# Altium schematic sheet MINIECO-ECO.SchDoc(MINIECO-ECO)
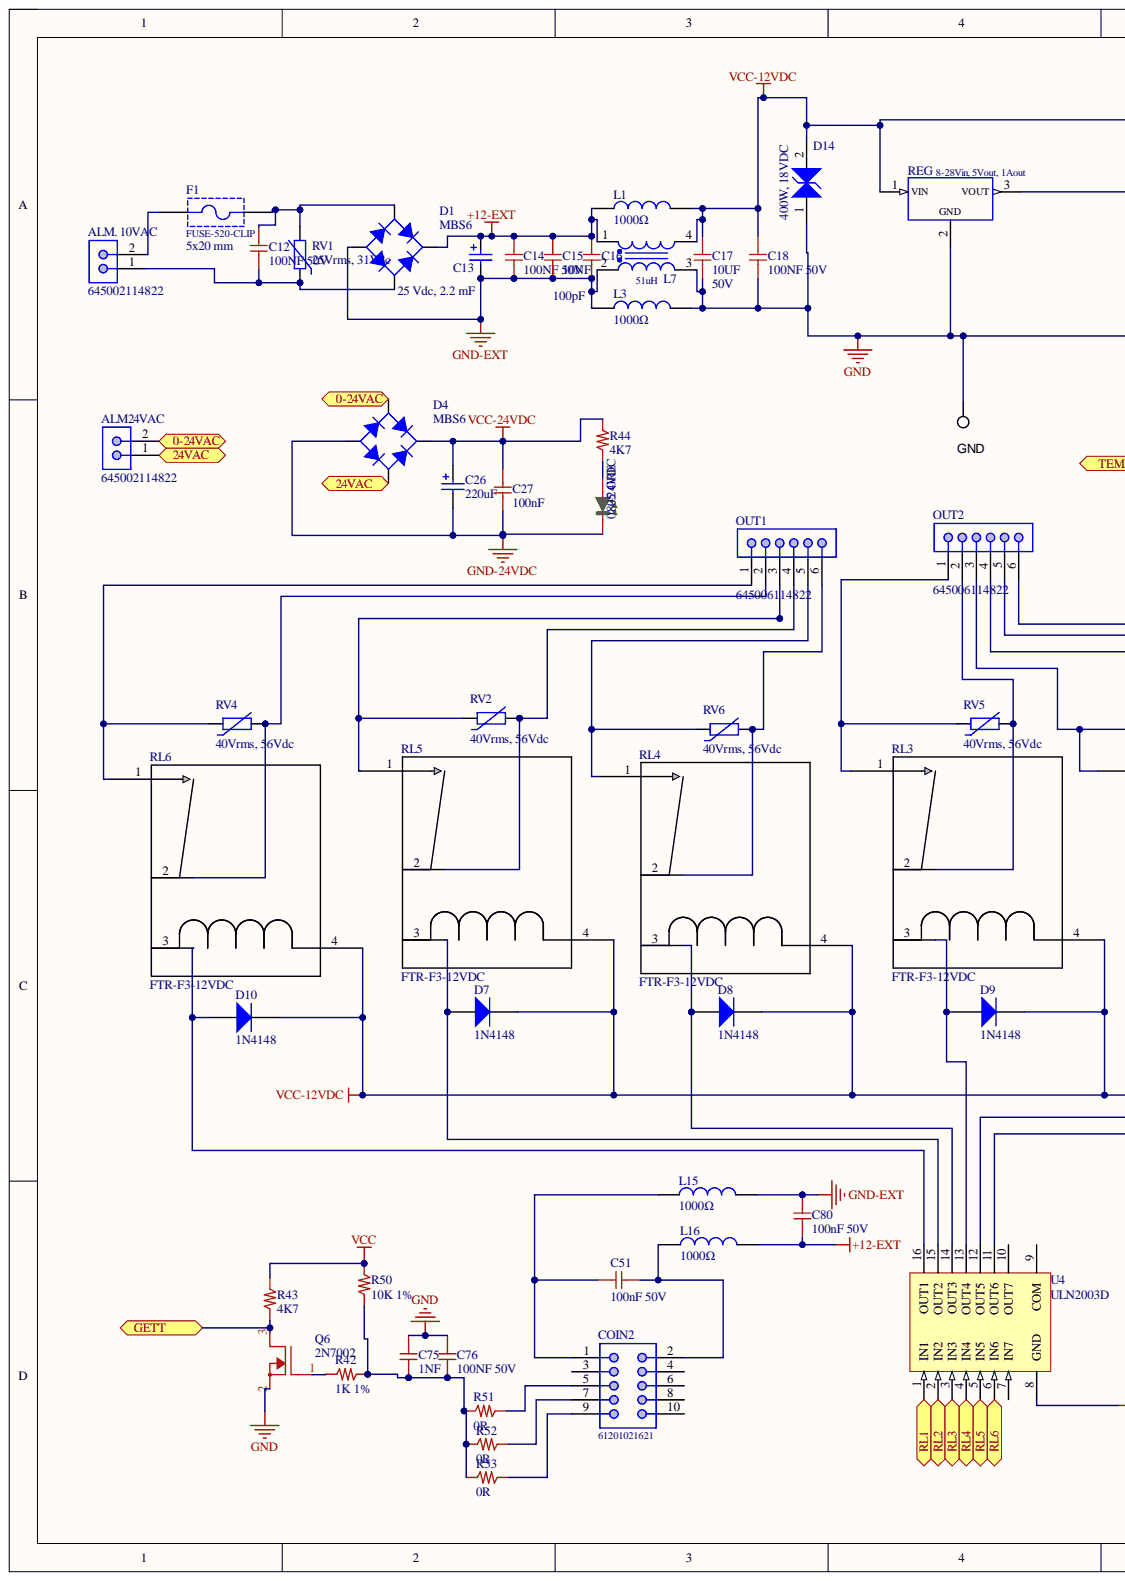
[diagram p0: MINIECO-ECO.SchDoc, left]
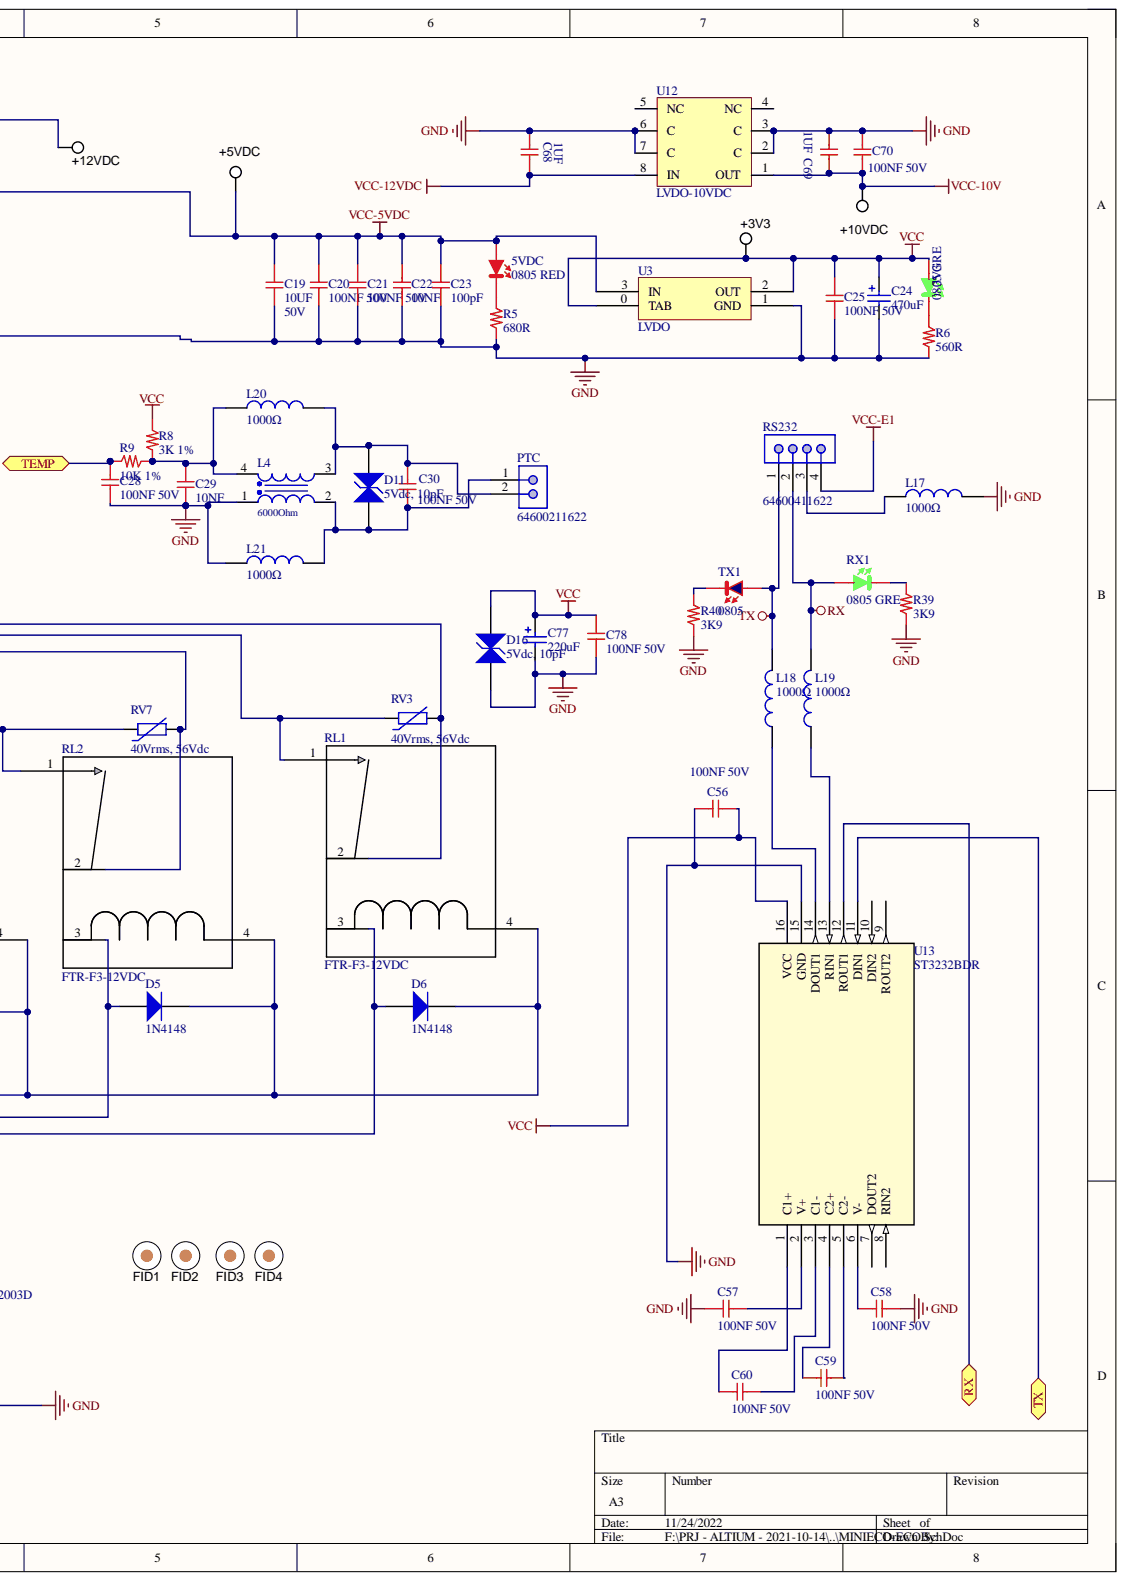
[diagram p1: MINIECO-ECO.SchDoc, right]

## Parts
3V3  0805 GRE  [0805_Green]
5VDC  0805 RED  [0805_Red]
+3V3  TEST POINT  [Keystone5012]  (value not drawn)
+5VDC  TEST POINT  [Keystone5012]  (value not drawn)
+10VDC  TEST POINT  [Keystone5012]  (value not drawn)
+12VDC  TEST POINT  [Keystone5012]  (value not drawn)
+24VDC  0805 GRE  [0805_Green]
ALM24VAC  645002114822  [645002114822]
ALM._10VAC  645002114822  [645002114822]
C12  100NF 50V  [0603_c]
C13  25 Vdc, 2.2 mF  value 2.2 mF  [WCAP-ATLI_13x35x5]
C14  100NF 50V  [0603_c]
C15  10NF  [0603_c]
C16  100pF  [0603_c]
C17  10UF  Tensione: 50V  [1206_C]
C18  100NF 50V  [0603_c]
C19  10UF  Tensione: 50V  [1206_C]
C20  100NF 50V  [0603_c]
C21  100NF 50V  [0603_c]
C22  10NF  [0603_c]
C23  100pF  [0603_c]
C24  value 470uF  [WCAP-ASLL_8x10.5]  (hidden comment: 865060253008)
C25  100NF 50V  [0603_c]
C26  value 220uF  [WCAP-ASLL_10x10.5]  (hidden comment: 865060657012)
C27  100nF  [0805_C]
C28  100NF 50V  [0603_c]
C29  10NF  [0603_c]
C30  100NF 50V  [0603_c]
C51  100nF 50V  [0603_c]
C56  100NF 50V  [0603_c]
C57  100NF 50V  [0603_c]
C58  100NF 50V  [0603_c]
C59  100NF 50V  [0603_c]
C60  100NF 50V  [0603_c]
C68  1UF  [0805_C]
C69  1UF  [0805_C]
C70  100NF 50V  [0603_c]
C75  1NF  [0603_c]
C76  100NF 50V  [0603_c]
C77  value 220uF  [WCAP-ASLL_10x10.5]  (hidden comment: 865060657012)
C78  100NF 50V  [0603_c]
C80  100nF 50V  [0603_c]
COIN2  61201021621  [61201021621]
D1  MBS6  [HD01-T SOP4]
D4  MBS6  [HD01-T SOP4]
D5  1N4148  [1N4148]
D6  1N4148  [1N4148]
D7  1N4148  [1N4148]
D8  1N4148  [1N4148]
D9  1N4148  [1N4148]
D10  1N4148  [1N4148]
D11  5Vdc, 10pF  [WE-VE_0603]
D14  400W, 18VDC  [WE-TVSP_DO-214AC_Bidirectional]
D16  5Vdc, 10pF  [WE-VE_0603]
F1  FUSE-520-CLIP  Fuse Size: 5x20 mm  [FUSE-520-CLIP]
FID1  Fiducial  [Fiducial10-20]  (value not drawn)
FID2  Fiducial  [Fiducial10-20]  (value not drawn)
FID3  Fiducial  [Fiducial10-20]  (value not drawn)
FID4  Fiducial  [Fiducial10-20]  (value not drawn)
GND  TEST POINT  [Keystone5012]  (value not drawn)
L1  1000Ω  [WE-CBF_0805_Wide Band]
L3  1000Ω  [WE-CBF_0805_Wide Band]
L4  6000Ohm  [WE-CNSW_1206]
L7  51uH  [WE-SL2]
L15  1000Ω  [WE-CBF_0805_Wide Band]
L16  1000Ω  [WE-CBF_0805_Wide Band]
L17  1000Ω  [WE-CBF_0805_Wide Band]
L18  1000Ω  [WE-CBF_0805_Wide Band]
L19  1000Ω  [WE-CBF_0805_Wide Band]
L20  1000Ω  [WE-CBF_0805_Wide Band]
L21  1000Ω  [WE-CBF_0805_Wide Band]
OUT1  645006114822  [645006114822]
OUT2  645006114822  [645006114822]
PTC  64600211622  [64600211622]
Q6  2N7002  [SOT23]
R5  680R  [0603_R]
R6  560R  [0603_R]
R8  3K 1%  [0805_R]
R9  10K 1%  [0603_R]
R39  3K9  [0603_R]
R40  3K9  [0603_R]
R42  1K 1%  [0603_R]
R43  4K7  [0603_R]
R44  4K7  [2512_R]
R50  10K 1%  [0603_R]
R51  0R  [0603_R]
R52  0R  [0603_R]
R53  0R  [0603_R]
REG  8-28Vin, 5Vout, 1Aout  [173010x78]
RL1  FTR-F3-12VDC  [PAN_ALD]
RL2  FTR-F3-12VDC  [PAN_ALD]
RL3  FTR-F3-12VDC  [PAN_ALD]
RL4  FTR-F3-12VDC  [PAN_ALD]
RL5  FTR-F3-12VDC  [PAN_ALD]
RL6  FTR-F3-12VDC  [PAN_ALD]
RS232  64600411622  [64600411622]
RV1  25Vrms, 31Vdc  [WE-VD_7mm_820572501]
RV2  40Vrms, 56Vdc  DC Operating Voltage: 56Vdc  [WE-VD_7mm_820574001]
RV3  40Vrms, 56Vdc  DC Operating Voltage: 56Vdc  [WE-VD_7mm_820574001]
RV4  40Vrms, 56Vdc  DC Operating Voltage: 56Vdc  [WE-VD_7mm_820574001]
RV5  40Vrms, 56Vdc  DC Operating Voltage: 56Vdc  [WE-VD_7mm_820574001]
RV6  40Vrms, 56Vdc  DC Operating Voltage: 56Vdc  [WE-VD_7mm_820574001]
RV7  40Vrms, 56Vdc  DC Operating Voltage: 56Vdc  [WE-VD_7mm_820574001]
RX1  0805 GRE  [0805_Green]
TX1  0805  [0805-BLU]
U3  LVDO  [SOT-223]
U4  ULN2003D  [SO16_N]
U12  LVDO-10VDC  [SO-8]
U13  ST3232BDR  [D16]

## Ports
0-24VAC, 24VAC, GETT, RL1, RL2, RL3, RL4, RL5, RL6, RX, TEMP, TX

## Nets
+12-EXT: C13.1 C14.1 C15.1 C16.1 C80.1 D1.1 L1.1 L7.1 L16.2
GND: C17.2 C18.2 C19.2 C20.2 C21.2 C22.2 C23.2 C24.2 C25.2 C28.2 C29.2 C56.1 C57.1 C58.2 C68.1 C69.1 C70.1 C75.1 C76.1 C77.2 C78.2 D14.1 D16.2 GND.1 L3.2 L4.1 L7.3 L17.2 L21.1 Q6.2 R5.2 R6.2 R39.1 R40.1 REG.2 U3.1(GND) U4.8(GND) U12.2(C) U12.3(C) U12.6(C) U12.7(C) U13.15(GND)
GND-24VDC: +24VDC.K C26.2 C27.2 D4.2
GND-EXT: C13.2 C14.2 C15.2 C16.2 C80.2 D1.2 L3.1 L7.2 L15.2
RX: L19.2 RS232.2 RX1.A
TX: L18.2 RS232.1 TX1.A
VCC: 3V3.A +3V3.1 C24.1 C25.1 C56.2 C77.1 C78.1 D16.1 R8.1 R43.1 R50.2 U3.0(TAB) U3.2(OUT) U13.16(VCC)
VCC-5VDC: 5VDC.A +5VDC.1 C19.1 C20.1 C21.1 C22.1 C23.1 REG.3 U3.3(IN)
VCC-10V: +10VDC.1 C69.2 C70.2 U12.1(OUT)
VCC-12VDC: +12VDC.1 C17.1 C18.1 C68.2 D5.2 D6.2 D7.2 D8.2 D9.2 D10.2 D14.2 L1.2 L7.4 REG.1 RL1.4 RL2.4 RL3.4 RL4.4 RL5.4 RL6.4 U12.8(IN)
VCC-24VDC: C26.1 C27.1 D4.1 R44.1
VCC-E1: RS232.4
0-24VAC: ALM24VAC.2 D4.4 ; port 0-24VAC
24VAC: ALM24VAC.1 D4.3 ; port 24VAC
GETT: Q6.3 R43.2 ; port GETT
RL1: U4.1(IN1) ; port RL1
RL2: U4.2(IN2) ; port RL2
RL3: U4.3(IN3) ; port RL3
RL4: U4.4(IN4) ; port RL4
RL5: U4.5(IN5) ; port RL5
RL6: U4.6(IN6) ; port RL6
TEMP: C28.1 R9.1 ; port TEMP
Net3V3_K: 3V3.K R6.1
Net5VDC_K: 5VDC.K R5.1
Net+24VDC_A: +24VDC.A R44.2
NetALM. 10VAC_1: ALM._10VAC.1 C12.2 D1.3 RV1.1
NetALM. 10VAC_2: ALM._10VAC.2 F1.1
NetC12_1: C12.1 D1.4 F1.2 RV1.2
NetC29_1: C29.1 L4.4 L20.1 R8.2 R9.2
NetC30_1: C30.1 D11.1 L4.2 L21.2 PTC.1
NetC30_2: C30.2 D11.2 L4.3 L20.2 PTC.2
NetC51_1: C51.1 COIN2.1 L15.1
NetC51_2: C51.2 COIN2.2 L16.1
NetC57_2: C57.2 U13.2(V+)
NetC58_1: C58.1 U13.6(V-)
NetC59_1: C59.1 U13.4(C2+)
NetC59_2: C59.2 U13.5(C2-)
NetC60_1: C60.1 U13.1(C1+)
NetC60_2: C60.2 U13.3(C1-)
NetC75_2: C75.2 C76.2 R42.2 R50.1 R51.1 R52.2 R53.1
NetCOIN2_5: COIN2.5 R51.2
NetCOIN2_7: COIN2.7 R52.1
NetCOIN2_9: COIN2.9 R53.2
NetD5_1: D5.1 RL2.3 U4.12(OUT5)
NetD6_1: D6.1 RL1.3 U4.11(OUT6)
NetD7_1: D7.1 RL5.3 U4.15(OUT2)
NetD8_1: D8.1 RL4.3 U4.14(OUT3)
NetD9_1: D9.1 RL3.3 U4.13(OUT4)
NetD10_1: D10.1 RL6.3 U4.16(OUT1)
NetL17_1: L17.1 RS232.3
NetL18_1: L18.1 U13.14(DOUT1)
NetL19_1: L19.1 U13.13(RIN1)
NetOUT1_1: OUT1.1 RL6.1 RV4.1
NetOUT1_2: OUT1.2 RL6.2 RV4.2
NetOUT1_3: OUT1.3 RL5.1 RV2.1
NetOUT1_4: OUT1.4 RL5.2 RV2.2
NetOUT1_5: OUT1.5 RL4.1 RV6.1
NetOUT1_6: OUT1.6 RL4.2 RV6.2
NetOUT2_1: OUT2.1 RL3.1 RV5.1
NetOUT2_2: OUT2.2 RL3.2 RV5.2
NetOUT2_3: OUT2.3 RL2.1 RV7.1
NetOUT2_4: OUT2.4 RL2.2 RV7.2
NetOUT2_5: OUT2.5 RL1.1 RV3.1
NetOUT2_6: OUT2.6 RL1.2 RV3.2
NetQ6_1: Q6.1 R42.1
NetR39_2: R39.2 RX1.K
NetR40_2: R40.2 TX1.K
NetU13_11: U13.11(DIN1) ; port TX
NetU13_12: U13.12(ROUT1) ; port RX

Not connected: COIN2 3 4 6 8 10; U4 7(IN7) 9(COM) 10(OUT7); U12 4(NC) 5(NC); U13 7(DOUT2) 8(RIN2) 9(ROUT2) 10(DIN2)

## Library data of the parts
3V3 +24VDC RX1 = LED_Verde_0805: LED Verde 0805; Cod. Interno: LEDS 9AC080GS7500; Cod. Produttore: 150080GS75000; Luminosità: 450mcd; Produttore: WE; V: 3.1V; Component Kind: Standard; Library Name: DiodiLED.SchLib
5VDC = LED_ROSSO_0805: LED Rosso 0805; Cod. Interno: LEDS 2AC080RS7500; Cod. Produttore: 150080RS75000; Luminosità: 150mcd; Produttore: WE; V: 2.0V; Component Kind: Standard; Library Name: DiodiLED.SchLib
+3V3 +5VDC +10VDC +12VDC GND = TP-HSW: Test Point, Multipurpose, White, TH; Manufacturer: Keystone; PartNumber: 5012; CompType: Test Point Loop; ContactFinish: Silver; Color: White; Component Kind: Standard; ComponentLink1Description: Datasheet URL; ComponentLink1URL: http://www.keyelco.com/userAssets/file/M60-2p60.pdf; LatestRevisionDate: 2017-01-19; Library Name: HSW.SchLib; MountType: TH; PackageReference: White Multipurpose Testpoint; RoHS: Y; Supplier 1: Digi-Key; Supplier 2: Mouser; Supplier Part Number 1: 36-5012-ND; Supplier Part Number 2: 534-5012; SymbolVersion: 2008-04-04; Publisher: Texas Instruments
ALM24VAC ALM._10VAC = 645002114822: WR-WTB THT Male Vertical Locking Header, pitch 3.96mm, 2p; Contact Resistance: 20mOhm; Pin Number: 2; Rated Current: 7A; Withstanding Voltage: 1500VAC; Working Voltage: 250VAC; Component Kind: Standard; ComponentLink1Description: Manufacturer; ComponentLink1URL: http://www.we-online.de; ComponentLink2Description: Datasheet; ComponentLink2URL: https://www.we-online.com/catalog/datasheet/645002114822.pdf; Gender: Male; Library Name: WR-WTB_3.96mm.SchLib; Mounting Technology: THT; Operating Temperature: -40 to +105degC; Packaging: Bag; Part Number: 645002114822; Pitch: 3.96mm; Published Date: January 2020; Type: Vertical; Publisher: Wurth Elektronik
C12 C14 C18 C20 C21 C25 C28 C30 C51 C56-C60 C70 C76 C78 C80 = 100NF 50V: Cap X7R 100nF 50V Flex Terminal; Cod. Interno: COCS50665050104; Cod. Produttore: CL10B104KB8SFNC; Produttore: Samsung; Cod. DigiKey: 1276-1936-2-ND; Component Kind: Standard; Library Name: CapSMD0603.SchLib
C13 = 860080478024: WCAP-ATLI Aluminum Electrolytic Capacitors, 13x35mm, 25 Vdc, 2.2 mF; Rated Voltage: 25 Vdc; Value: 2.2 mF; Component Kind: Standard; ComponentLink1Description: Manufacturer; ComponentLink1URL: http://www.we-online.de; ComponentLink2Description: Datasheet; ComponentLink2URL: http://katalog.we-online.com/pbs/datasheet/860080478024.pdf; Library Name: WCAP-ATLI.SchLib; Life Cycle: 5000h; Operating Temperature: -55 °C up to +105 °C; Part Number: 860080478024; Published Date: Sep. 2017; Size: 13x35mm; Publisher: Wurth Elektronik
C15 C22 C29 = 10NF 50V: Cap X7R 10nF 50V; Cod. Interno: COCS 556655000103; Cod. Produttore: CL05B103KB5NNNC; Produttore: Samsung; Cod. DigiKey: 1276-1028-2-ND; Component Kind: Standard; Library Name: CapSMD0603.SchLib
C16 C23 = 100pF 50V: Cap X7R 100pF 50V; Cod. Interno: COCS??; Cod. Produttore: ??; Component Kind: Standard; Library Name: CapSMD0603.SchLib; Produttore: ??
C17 C19 = 10UF 50V: Cap X5R 10UF 50V; Cod. Produttore: GRM31CR61H106KA12L; Produttore: MURATA; Cod. Farnell: 2666583; Component Kind: Standard; Library Name: CapSMD1206.SchLib; Temperature Range: Da -55°C a +125°C
C24 = 865060253008: WCAP-ASLL Aluminum Electrolytic Capacitors, size Ф8x10.5mm, 10V DC, 470uF; Rated Voltage: 10V DC; Component Kind: Standard; ComponentLink1Description: Manufacturer; ComponentLink1URL: http://www.we-online.de; ComponentLink2Description: Datasheet; ComponentLink2URL: http://katalog.we-online.de/pbs/datasheet/865060253008.pdf; Library Name: WCAP-ASLL.SchLib; Life Cycle: 5000h; Operating Temperature: -55 °C up to +105 °C; Part Number: 865060253008; Published Date: June 2017; Size: Ф8x10.5mm; Publisher: Wurth Elektronik
C26 C77 = 865060657012: WCAP-ASLL Aluminum Electrolytic Capacitors, size Ф10x10.5mm, 50V DC, 220uF; Rated Voltage: 50V DC; Component Kind: Standard; ComponentLink1Description: Manufacturer; ComponentLink1URL: http://www.we-online.de; ComponentLink2Description: Datasheet; ComponentLink2URL: http://katalog.we-online.de/pbs/datasheet/865060657012.pdf; Library Name: WCAP-ASLL.SchLib; Life Cycle: 5000h; Operating Temperature: -55 °C up to +105 °C; Part Number: 865060657012; Published Date: June 2017; Size: Ф10x10.5mm; Publisher: Wurth Elektronik
C27 = 100nF 100V: Cap X7R 100nF 100V; Cod. Interno: COCS 557051010104; Cod. Produttore: ??; Component Kind: Standard; Library Name: CapSMD0805.SchLib; Produttore: ??
C68 C69 = 1UF 100V: Cap X7S 1UF 100V; Cod. Interno: COCS 567061010105; Cod. Produttore: C2012X7S2A105K125AE; Produttore: TDK; Cod. DigiKey: 445-8887-2-ND; Component Kind: Standard; Library Name: CapSMD0805.SchLib; Temperature Range: Da -55°C a +125°C
C75 = 1NF 50V: Cap X7R 1nF 50V; Cod. Interno: COCS 50665050102; Component Kind: Standard; Library Name: CapSMD0603.SchLib
COIN2 = 61201021621: Male Box Header WR-BHD, THT, Vertical, pitch 2.54 mm, 10 pins; Contact Resistance: 20 mOhm; Pin Number: 10; Pitch: 2.54 mm; Withstanding Voltage: 500 VAC; Working Voltage: 250 VAC; Component Kind: Standard; ComponentLink1Description: Manufacturer; ComponentLink1URL: http://www.we-online.de; ComponentLink2Description: Datasheet; ComponentLink2URL: https://katalog.we-online.de/em/datasheet/6120xx21621.pdf; Gender: Male; Mounting Technology: THT; Operating Temperature: -40 to 125 degC; Orientation: Vertical; Packaging: Tray; Part Number: 61201021621; Published Date: August 2018; Library Name: CONN-FLAT.SchLib; Publisher: Wurth Elektronik
D1 D4 = HD01-T: Full Wave Diode Bridge; Component Kind: Standard; Library Name: HSW.SchLib; Publisher: Altium Limited
D5-D10 = 1N4148: High Conductance Fast Diode; Code_JEDEC: DO-204-AH; Component Kind: Standard; ComponentLink1Description: Manufacturer Link; ComponentLink1URL: http://www.fairchildsemi.com/; ComponentLink2Description: Datasheet; ComponentLink2URL: http://www.fairchildsemi.com/ds/1N%2F1N4148.pdf; DatasheetVersion: 1997; LatestRevisionDate: 17-Jul-2002; LatestRevisionNote: Re-released for DXP Platform.; PackageDescription: Diode, Axial; Body 3.8 x 1.9 mm (LxDia. max), Lead Dia. 0.53 mm (max); PackageReference: DO-35; Published: 8-Jun-2000; Library Name: HSW.SchLib; Publisher: Altium Limited
D11 D16 = 82356050100: WE-VE ESD Suppressor, size 0603, 5Vdc, 10pF; Clamp Voltage: 60V; ESD Voltage air discharge: 15kV; Leakage Current: 1uA; Capacitance: 10pF; Component Kind: Standard; ComponentLink1Description: Manufacturer; ComponentLink1URL: http://www.we-online.de; ComponentLink2Description: Datasheet; ComponentLink2URL: https://katalog.we-online.com/pbs/datasheet/82356050100.pdf; Library Name: WE-VE_0603.SchLib; Mounting Technology: SMT; Operating Temperature: -40 to +85degC; Packaging: Tape and Reel; Part Number: 82356050100; Published Date: June 2019; Size: 0603; Working Voltage: 5Vdc; Publisher: Wurth Elektronik
D14 = 824501181: WE-TVSP Power TVS Diode, DO-214AC, 400W, 18VDC, Bidirectional; (Forward) Peak Pulse Current: 13.7A; (Reverse) Breakdown Voltage: 21.05V; Clamping Voltage: 29.2V; DC Operating Voltage: 18V; Component Kind: Standard; ComponentLink1Description: Manufacturer; ComponentLink1URL: http://www.we-online.de; ComponentLink2Description: Datasheet; ComponentLink2URL: https://katalog.we-online.com/pbs/datasheet/824501181.pdf; Library Name: WE-TVSP_DO-214AC_Bidirectional.SchLib; Mounting Technology: SMT; Operating Temperature: -65 to +150degC; Packaging: Tape and Reel; Part Number: 824501181; Polarity: Bidirectional; Published Date: March 2019; Size: DO-214AC; Steady State Power Dissipation: 400W; Publisher: Wurth Elektronik
F1 = FUSE-520-CLIP: LITTELFUSE-520 Series Circuit Board Mount Fuse Clips for 5mm & 6.3mm Diameter Fuses; Part Number: 696108003002; Component Kind: Standard; ComponentLink1Description: Manufacturer; ComponentLink1URL: http://www.we-online.de; ComponentLink2Description: Datasheet; ComponentLink2URL: https://katalog.we-online.de/em/datasheet/696108003002.pdf; Mounting Technology: THT; Operating Temperature: -30 to 120 degC; Packaging: Box; Published Date: August 2018; Library Name: HSW.SchLib; Publisher: Wurth Elektronik
FID1-FID4 = Fiducial: Fiducial mark.  There is nothing to buy or mount.; SymbolCreator: codlsc; ClassName: FiducialMark; Component Kind: Standard; CompType: Fiducial; LatestRevisionDate: 2015-10-18; LatestRevisionNote: Added Alternate PartNumber and Alternate Manufacturer parameters to appropriate components.; Manufacturer: N/A; MountType: N/A; PackageReference: N/A; PartNumber: N/A; SymbolVersion: 2009-11-4; Library Name: HSW.SchLib; Publisher: Texas Instruments
L1 L3 L15-L21 = WE-CBF, 74279205: WE-CBF SMD EMI Suppression Ferrite Bead, 0805, 1000Ω; Type: Wide Band; Component Kind: Standard; ComponentLink1Description: Manufacturer; ComponentLink1URL: http://www.we-online.de; ComponentLink2Description: Datasheet; ComponentLink2URL: http://katalog.we-online.de/pbs/datasheet/74279205.pdf; Library Name: WE-CBF_0805.SchLib; PartNumber: 74279205; PublishedDate: Aug 2016; Size: 0805; Value: 1000Ω; Publisher: Wurth Elektronik
L4 = 744232101: WE-CNSW SMT Common Mode Line Filter, size 1206, 6000Ohm, 0.09A, 50V; Manufacturer: Wurth Elektronik; DC Resistance max.: 5.5Ohm; Impedance Test Condition: 100MHz; Insulation Test Voltage: 125VAC; Winding Style: bifiliar; Component Kind: Standard; ComponentLink1Description: Manufacturer; ComponentLink1URL: http://www.we-online.de; ComponentLink2Description: Datasheet; ComponentLink2URL: https://www.we-online.com/catalog/datasheet/744232101.pdf; Impedance: 6000Ohm; Library Name: WE-CNSW_1206.SchLib; Manufacturer Part Number: 744232101; Mounting Technology: SMT; Operating Temperature: -40 to +125degC; Packaging: Tape and Reel; Published Date: April 2020; Rated Current: 0.09A; Rated Voltage: 50V; Size: 1206
L7 = 744227S: WE-SL2 SMT Common Mode Line Filter, 51uH, 1000mA, 80V; DC Resistance max.: 0.16Ohm; Impedance max.: 5500Ohm; Insulation Test Voltage: 500V (AC); Leakage Inductance: 3150nH; Winding Style: sectional; Component Kind: Standard; ComponentLink1Description: Manufacturer; ComponentLink1URL: http://www.we-online.de; ComponentLink2Description: Datasheet; ComponentLink2URL: https://katalog.we-online.com/pbs/datasheet/744227S.pdf; Inductance: 51uH; Library Name: WE-SL2.SchLib; Mounting Technology: SMT; Operating Temperature: -40 to +125degC; Packaging: Tape and Reel; Part Number: 744227S; Published Date: March 2019; Rated Current: 1000mA; Rated Voltage: 80V; Publisher: Wurth Elektronik
OUT1 OUT2 = 645006114822: WR-WTB THT Male Vertical Locking Header, pitch 3.96mm, 6p; Contact Resistance: 20mOhm; Pin Number: 6; Rated Current: 7A; Withstanding Voltage: 1500VAC; Working Voltage: 250VAC; Component Kind: Standard; ComponentLink1Description: Manufacturer; ComponentLink1URL: http://www.we-online.de; ComponentLink2Description: Datasheet; ComponentLink2URL: https://www.we-online.com/catalog/datasheet/645006114822.pdf; Gender: Male; Library Name: WR-WTB_3.96mm.SchLib; Mounting Technology: THT; Operating Temperature: -40 to +105degC; Packaging: Bag; Part Number: 645006114822; Pitch: 3.96mm; Published Date: January 2020; Type: Vertical; Publisher: Wurth Elektronik
PTC = 64600211622: WR-WTB Male Vertical Shrouded Header, pitch 2.5mm, 2p; Contact Resistance: 20mOhm; Pin Number: 2; Rated Current: 3A; Withstanding Voltage: 1000VAC; Working Voltage: 250VAC; Component Kind: Standard; ComponentLink1Description: Manufacturer; ComponentLink1URL: http://www.we-online.de; ComponentLink2Description: Datasheet; ComponentLink2URL: https://www.we-online.com/catalog/datasheet/64600211622.pdf; Gender: Male; Library Name: WR-WTB_2.50mm.SchLib; Mounting Technology: THT; Operating Temperature: -40 to +105degC; Packaging: Bag; Part Number: 64600211622; Pitch: 2.5mm; Published Date: January 2020; Type: Vertical; Publisher: Wurth Elektronik
Q6 = 2N7002: N-Channel 60V 0.3A MosFET; Cod. Interno: TRXS1100002N7002; Produttore: NXP; Cod. Produttore: 2N7002; Component Kind: Standard; Library Name: MosfetSMD.SchLib
R5 = 680R: Res Film Spesso 1/16W 680R 5%; Cod. Interno: RESS 4166556B0681; Component Kind: Standard; Library Name: ResSMD0603.SchLib
R6 = 560R: Res Film Spesso 1/16W 560R 5%; Cod. Interno: RESS 4166556B0561; Component Kind: Standard; Library Name: ResSMD0603.SchLib
R8 = 3K 1%: Res Film Spesso 0805 1/2W 3K 1%; Cod. Interno: ??; Component Kind: Standard; Library Name: ResSMD0805.SchLib
R9 R50 = 10K 1%: Res Film Spesso 1/16W 10K 1%; Cod. Interno: RESS4166356B0103; Cod. Produttore: ERJ-3EKF1002V; Produttore: Panasonic; Component Kind: Standard; Library Name: ResSMD0603.SchLib
R39 R40 = 3K9: Res Film Spesso 1/16W 3K9 5%; Cod. Interno: RESS4166556B0392; Cod. Produttore: CRCW06033K90JNEA; Produttore: VISHAY; Component Kind: Standard; Library Name: ResSMD0603.SchLib
R42 = 1K 1%: Res Film Spesso 1/16W 1K 1%; Cod. Interno: RESS4166356B0102; Cod. Produttore: ??; Component Kind: Standard; Library Name: ResSMD0603.SchLib; Produttore: ??
R43 = 4K7: Res Film Spesso 1/16W 4K7 5%; Cod. Interno: RESS4166556B0472; Component Kind: Standard; Library Name: ResSMD0603.SchLib
R44 = 4K7: Precision Thick Film 4K7 1W 1%; Cod. Interno: RESS 4190310A54R9; Cod. Produttore: ERJ-1TNF54R9U; Produttore: Vishay Dale; Cod. DigiKey: PT54.9AFTR-ND; Component Kind: Standard; Library Name: ResSMD2512.SchLib
R51-R53 = 0R: Res Film Spesso 1/16W 0R 5%; Cod. Interno: RESS 4166556B0000; Component Kind: Standard; Library Name: ResSMD0603.SchLib
REG = 173010578: MagI3C-FDSM Fixed Step Down Regulator Module, SIP-3, 8-28Vin, 5Vout, 1Aout; Input Voltage: 8-28V; Output Current: 1A; Output Voltage: 5V; Component Kind: Standard; ComponentLink1Description: Manufacturer; ComponentLink1URL: http://www.we-online.de; ComponentLink2Description: Datasheet; ComponentLink2URL: https://www.we-online.com/catalog/datasheet/173010578.pdf; Height: 10.5mm; Length: 11.6mm; Library Name: MagI3C-FDSM.SchLib; Manufacturer Part Number: 173010578; Mounting Technology: THT; Operating Temperature: -40 to +85degC; Packaging: Tube; Published Date: March 2020; Size: SIP-3; Width: 8.5mm; Publisher: Wurth Elektronik
RL1-RL6 = FTR-F3-12VDC: RELE NA 5A 250VAC COIL12VDC; Manufacturer_Part_Number: ALDP106W; Built_By: EMA_UL_Team; Vendor: Panasonic; Component Kind: Standard; Library Name: FTR-F3.SchLib; RefDes: RefDes; Type: DEV; Value: Value; Copyright: Copyright (C) 2018 Accelerated Designs. All rights reserved
RS232 = 64600411622: WR-WTB Male Vertical Shrouded Header, pitch 2.5mm, 4p; Contact Resistance: 20mOhm; Pin Number: 4; Rated Current: 3A; Withstanding Voltage: 1000VAC; Working Voltage: 250VAC; Component Kind: Standard; ComponentLink1Description: Manufacturer; ComponentLink1URL: http://www.we-online.de; ComponentLink2Description: Datasheet; ComponentLink2URL: https://www.we-online.com/catalog/datasheet/64600411622.pdf; Gender: Male; Library Name: WR-WTB_2.50mm.SchLib; Mounting Technology: THT; Operating Temperature: -40 to +105degC; Packaging: Bag; Part Number: 64600411622; Pitch: 2.5mm; Published Date: January 2020; Type: Vertical; Publisher: Wurth Elektronik
RV1 = 820572501: WE-VD Disk Varistor, size 7mm, 25Vrms, 31Vdc; (Channel) Input Capacitance: 1820pF; (Reverse) Breakdown Voltage: 39V; (Reverse) Peak Pulse Current: 250A; Clamping Voltage: 77V; Energy Absorbtion: 2.4J; Power Dissipation: 0.02W; AC Operating Voltage: 25Vrms; Component Kind: Standard; ComponentLink1Description: Manufacturer; ComponentLink1URL: http://www.we-online.de; ComponentLink2Description: Datasheet; ComponentLink2URL: https://katalog.we-online.com/pbs/datasheet/820572501.pdf; DC Operating Voltage: 31Vdc; Mounting Technology: THT; Operating Temperature: -40 to +85degC; Packaging: Tape and Reel; Part Number: 820572501; Published Date: March 2019; Size: 7mm; Library Name: MOV_7mm.SchLib; Publisher: Wurth Elektronik
RV2-RV7 = 820574001: WE-VD Disk Varistor, size 7mm, 40Vrms, 56Vdc; (Channel) Input Capacitance: 1120pF; (Reverse) Breakdown Voltage: 68V; (Reverse) Peak Pulse Current: 250A; Clamping Voltage: 135V; Energy Absorbtion: 4.3J; Power Dissipation: 0.02W; AC Operating Voltage: 40Vrms; Component Kind: Standard; ComponentLink1Description: Manufacturer; ComponentLink1URL: http://www.we-online.de; ComponentLink2Description: Datasheet; ComponentLink2URL: https://katalog.we-online.com/pbs/datasheet/820574001.pdf; Mounting Technology: THT; Operating Temperature: -40 to +85degC; Packaging: Tape and Reel; Part Number: 820574001; Published Date: March 2019; Size: 7mm; Library Name: MOV_7mm.SchLib; Publisher: Wurth Elektronik
TX1 = LED BLU 0805: Cod. Produttore: KPTR-3216QBC-D; Luminosità: 100mcd 20mA; Produttore: kingbright; Vf(typ): 3.3V; Component Kind: Standard; Description: LED BLU 0805; Library Name: DiodiLED.SchLib
U3 = LD1117S33TR: REGOLATORE IN; Component Kind: Standard; Library Name: HSW.SchLib
U4 = ULN2003D: Seven Darlington Array; Code_IPC: SOIC127P600-16; Component Kind: Standard; ComponentLink1Description: Manufacturer Link; ComponentLink1URL: http://www.st.com/; ComponentLink2Description: Datasheet; ComponentLink2URL: http://www.st.com/stonline/products/literature/ds/5279/uln2001.pdf; DatasheetVersion: Sep-1998; LatestRevisionDate: 13-Apr-2006; LatestRevisionNote: IPC-7351 Footprint Added.; PackageDescription: 16-Pin Small Outline Integrated Circuit 1.27 mm Pitch; PackageReference: SO16; PackageVersion: May-1998; Published: 8-Jun-2000; Library Name: HSW.SchLib; Publisher: Altium Limited
U12 = TL050L10CD-R: TEXAS-LOW-DROPOUT VOLTAGEREGULATORS-26VIN-10VOUT; Component Kind: Standard; Library Name: HSW.SchLib
U13 = ST3232BDR: 3 to 5.5 V, low-power, up to 400 kbs RS-232 drivers and receivers; Manufacturer_Part_Number: MAX3232IDR; Built_By: EMA_UL_Team; Vendor: Texas Instruments; Component Kind: Standard; RefDes: RefDes; Type: DEV; Value: Value; Copyright: Copyright (C) 2018 Accelerated Designs. All rights reserved; Library Name: HSW.SchLib
